# Altium schematic sheet VESC.SchDoc(VESC)
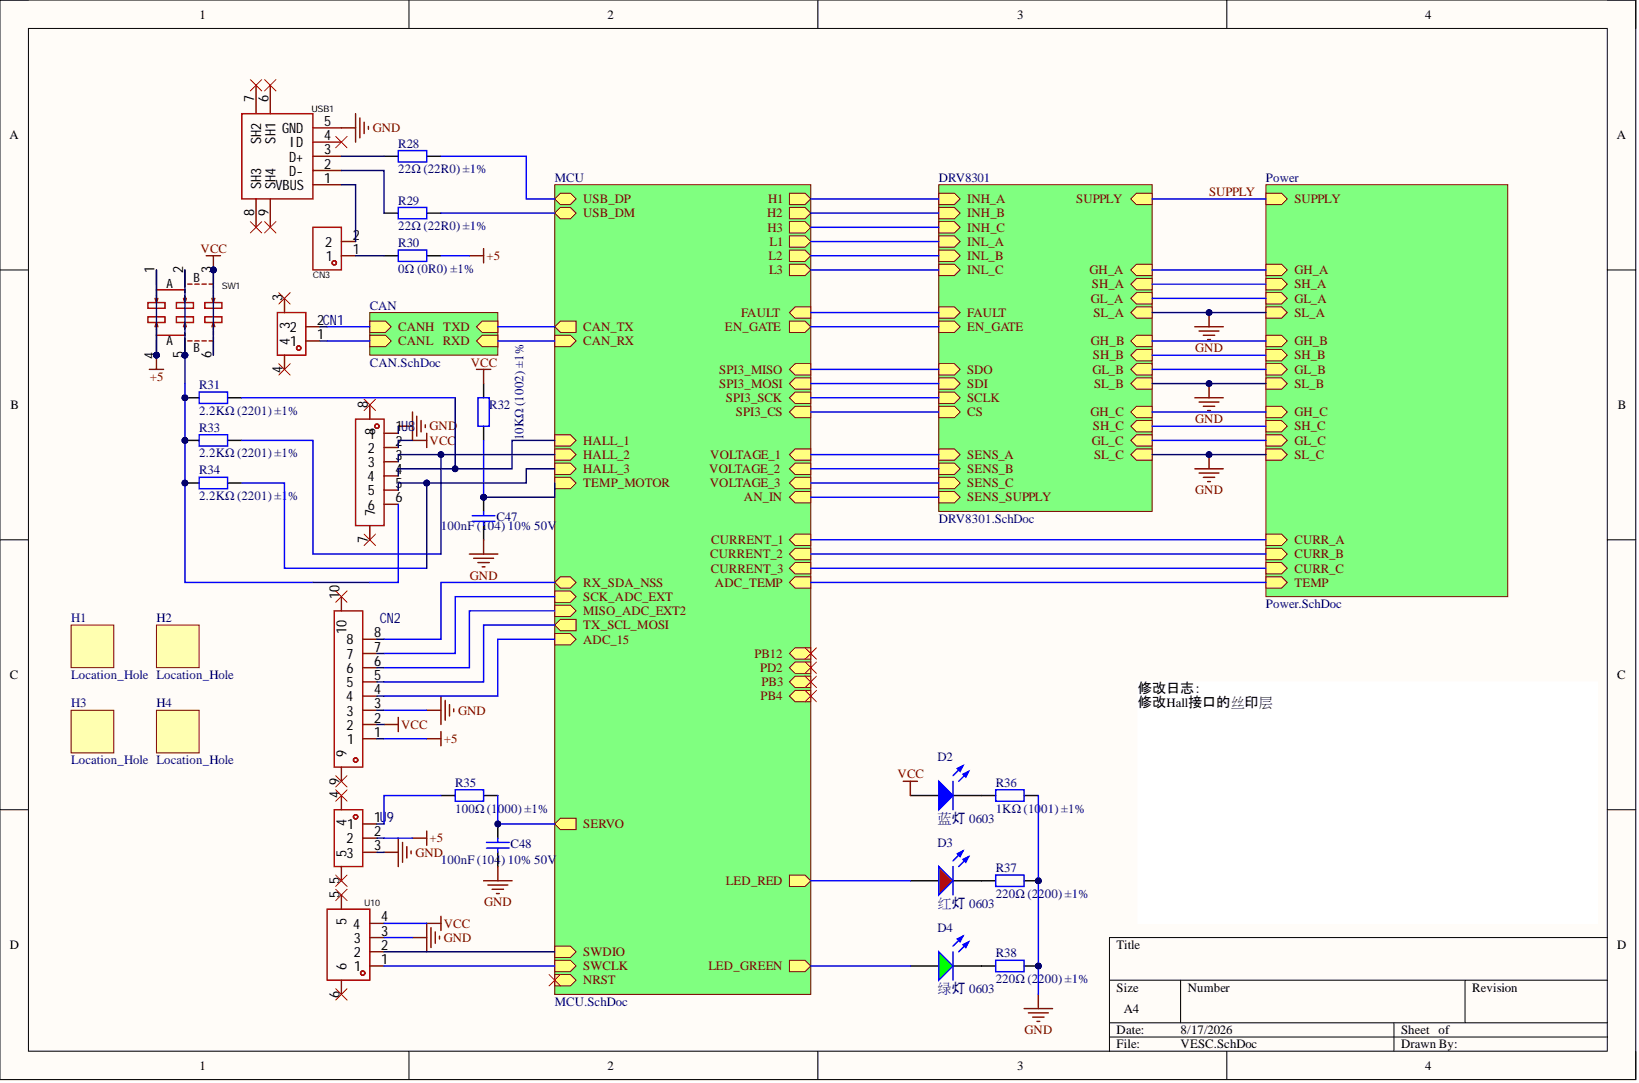
[diagram p0: VESC.SchDoc]

## Parts
C47  100nF (104) 10% 50V
C48  100nF (104) 10% 50V
CN1  GH1.25-2PWBPZ  (value not drawn)
CN2  GH1.25-8PWBPZ  (value not drawn)
CN3  DZ254S-11-02-48  (value not drawn)
D2  ?灯 0603  Package: 0603
D3  红灯 0603  Package: 0603
D4  绿灯 0603  Package: 0603
H1  Location_Hole
H2  Location_Hole
H3  Location_Hole
H4  Location_Hole
R28  22Ω (22R0) ±1%
R29  22Ω (22R0) ±1%
R30  0Ω (0R0) ±1%
R31  2.2KΩ (2201) ±1%
R32  10KΩ (1002) ±1%
R33  2.2KΩ (2201) ±1%
R34  2.2KΩ (2201) ±1%
R35  100Ω (1000) ±1%
R36  1KΩ (1001) ±1%
R37  220Ω (2200) ±1%
R38  220Ω (2200) ±1%
SW1  MST22D18G2  (value not drawn)
U8  GH1.25-6PWBPZ  (value not drawn)
U9  GH1.25-3PWBPZ  (value not drawn)
U10  WAFER-GH1.25-4PWB  (value not drawn)
USB1  MicroXNJ  (value not drawn)

## Nets
+5: CN2.1 R30.2 SW1.1 SW1.4 U9.2
GND: C47.1 C48.1 CN2.3 R36.2 R37.2 R38.2 U8.1 U9.3 U10.3 USB1.5(GND)
VCC: CN2.2 D2.A R32.2 SW1.3 SW1.6 U8.2 U10.4
NetC47_2: C47.2 R32.1
NetC48_2: C48.2 R35.2
NetCN1_1: CN1.1
NetCN1_2: CN1.2
NetCN1_3: CN1.3
NetCN1_4: CN1.4
NetCN2_4: CN2.4
NetCN2_5: CN2.5
NetCN2_6: CN2.6
NetCN2_7: CN2.7
NetCN2_8: CN2.8
NetCN2_9: CN2.9
NetCN2_10: CN2.10
NetCN3_1: CN3.1 R30.1
NetCN3_2: CN3.2 USB1.1(VBUS)
NetD2_K: D2.K R36.1
NetD3_A: D3.A
NetD3_K: D3.K R37.1
NetD4_A: D4.A
NetD4_K: D4.K R38.1
NetR28_1: R28.1 USB1.3(D+)
NetR28_2: R28.2
NetR29_1: R29.1 USB1.2(D-)
NetR29_2: R29.2
NetR31_1: R31.1 R33.1 R34.1 SW1.2 SW1.5 U8.6
NetR31_2: R31.2 U8.4
NetR33_2: R33.2 U8.3
NetR34_2: R34.2 U8.5
NetR35_1: R35.1 U9.1
NetU8_7: U8.7
NetU8_8: U8.8
NetU9_4: U9.4
NetU9_5: U9.5
NetU10_1: U10.1
NetU10_2: U10.2
NetU10_5: U10.5
NetU10_6: U10.6
NetUSB1_4: USB1.4(ID)
NetUSB1_6: USB1.6(SH1)
NetUSB1_7: USB1.7(SH2)
NetUSB1_8: USB1.8(SH3)
NetUSB1_9: USB1.9(SH4)
ADC_TEMP:
AN_IN:
CAN_RX:
CAN_TX:
CS:
CURR_A:
CURR_B:
CURR_C:
EN_GATE:
FAULT:
GH_A:
GH_B:
GH_C:
GL_A:
GL_B:
GL_C:
H1:
H2:
H3:
INL_A:
INL_B:
INL_C:
NRST:
PB3:
PB4:
PB12:
PD2:
SCLK:
SDI:
SDO:
SENS_A:
SENS_B:
SENS_C:
SH_A:
SH_B:
SH_C:
SUPPLY:

## Library data of the parts
C47 C48 = 0603 100nF (104) 10% 50V: ?片电容; Component Kind: Standard; ComponentLink1Description: 供应商?接; ComponentLink1URL: http://www.szlcsc.com/product/details_15331.html; Library Name: Miscellaneous Devices LC.IntLib; Package: 0603; Supplier: LC; SuppliersPartNumber: C14663
CN1 = GH1.25-2PWBPZ: Manufacturer: BOOMELE(博穆精密); Add into BOM: yes; Component Kind: Standard; Convert to PCB: yes; Datasheet: https://atta.szlcsc.com/upload/public/pdf/source/20211125/08A09A4909F58AF780B71FE423A00F7E.pdf; Device: GH1.25-2PWBPZ; JLCPCB Part Class: 扩展库; LCSC Part Name: GH1.25-2P卧?编带; Manufacturer Part: GH1.25-2PWBPZ; Supplier: LCSC; Supplier Footprint: -; Supplier Part: C2829251; Symbol: GH1.25-2PWBPZ; Library Name: VESC.SchLib
CN2 = GH1.25-8PWBPZ: Manufacturer: BOOMELE(博穆精密); Add into BOM: yes; Component Kind: Standard; Convert to PCB: yes; Datasheet: https://atta.szlcsc.com/upload/public/pdf/source/20211125/08A09A4909F58AF780B71FE423A00F7E.pdf; Device: GH1.25-8PWBPZ; JLCPCB Part Class: 扩展库; LCSC Part Name: GH1.25-8P卧?编带; Manufacturer Part: GH1.25-8PWBPZ; Supplier: LCSC; Supplier Footprint: -; Supplier Part: C2829257; Symbol: GH1.25-8PWBPZ; Library Name: VESC.SchLib
CN3 = DZ254S-11-02-48: Manufacturer: DEALON(德??); Add into BOM: yes; Circluar Pins/Square Pins: 方?; Pin Structure: 1x2P; Pitch: 2.54mm; Component Kind: Standard; Convert to PCB: yes; Datasheet: https://atta.szlcsc.com/upload/public/pdf/source/20230921/FE4E6D7F32A2CD9B95B1709A1ADE25FC.pdf; Device: DZ254S-11-02-48; JLCPCB Part Class: Extended Part; LCSC Part Name: ??:2.54mm 1x2P 立? 方?; Manufacturer Part: DZ254S-11-02-48; Mounting Type: 立?; Number of Pins: 2P; Number of Rows: 单排; Supplier: LCSC; Supplier Footprint: SMD,P=2.54mm; Supplier Part: C5160785; Symbol: DZ254S-11-02-48; Library Name: VESC.SchLib
D2 = 0603 ?灯: 发光二极管; Component Kind: Standard; ComponentLink1Description: 供应商?接; ComponentLink1URL: http://www.szlcsc.com/product/details_73150.html; Library Name: LED.SchLib; Supplier: LC; SuppliersPartNumber: C72041
D3 = 0603 红灯: 发光二极管; Component Kind: Standard; ComponentLink1Description: 供应商?接; ComponentLink1URL: http://www.szlcsc.com/product/details_2659.html; Library Name: LED.SchLib; Supplier: LC; SuppliersPartNumber: C2286
D4 = 0603 绿灯: 发光二极管; Component Kind: Standard; ComponentLink1Description: 供应商?接; ComponentLink1URL: http://www.szlcsc.com/product/details_73152.html; Library Name: LED.SchLib; Supplier: LC; SuppliersPartNumber: C72043
R28 R29 = 0603 22Ω (22R0) 1%: ?片电?; Component Kind: Standard; ComponentLink1Description: 供应商?接; ComponentLink1URL: http://www.szlcsc.com/product/details_24078.html; Library Name: Miscellaneous Devices LC.IntLib; Package: 0603; Supplier: LC; SuppliersPartNumber: C23345
R30 = 0603 0Ω (0R0) 1%: ?片电?; Component Kind: Standard; ComponentLink1Description: 供应商?接; ComponentLink1URL: http://www.szlcsc.com/product/details_21903.html; Library Name: Miscellaneous Devices LC.IntLib; Package: 0603; Supplier: LC; SuppliersPartNumber: C21189
R31 R33 R34 = 0603 2.2KΩ (2201) 1%: ?片电?; Component Kind: Standard; ComponentLink1Description: 供应商?接; ComponentLink1URL: http://www.szlcsc.com/product/details_4597.html; Library Name: Miscellaneous Devices LC.IntLib; Package: 0603; Supplier: LC; SuppliersPartNumber: C4190
R32 = 0603 10KΩ (1002) 1%: ?片电?; Component Kind: Standard; ComponentLink1Description: 供应商?接; ComponentLink1URL: http://www.szlcsc.com/product/details_26547.html; Library Name: Miscellaneous Devices LC.IntLib; Package: 0603; Supplier: LC; SuppliersPartNumber: C25804
R35 = 0603 100Ω (1000) 1%: ?片电?; Component Kind: Standard; ComponentLink1Description: 供应商?接; ComponentLink1URL: http://www.szlcsc.com/product/details_23502.html; Library Name: Miscellaneous Devices LC.IntLib; Package: 0603; Supplier: LC; SuppliersPartNumber: C22775
R36 = 0603 1KΩ (1001) 1%: ?片电?; Component Kind: Standard; ComponentLink1Description: 供应商?接; ComponentLink1URL: http://www.szlcsc.com/product/details_21904.html; Library Name: Miscellaneous Devices LC.IntLib; Package: 0603; Supplier: LC; SuppliersPartNumber: C21190
R37 R38 = 0603 220Ω (2200) 1%: ?片电?; Component Kind: Standard; ComponentLink1Description: 供应商?接; ComponentLink1URL: http://www.szlcsc.com/product/details_23689.html; Library Name: Miscellaneous Devices LC.IntLib; Package: 0603; Supplier: LC; SuppliersPartNumber: C22962
SW1 = MST22D18G2: Manufacturer: SHOU HAN(??); Add into BOM: yes; Circuit: 双刀双掷; Current Rating (DC): 50mA; Mechanical Life: 1万次; Pin Style: SMD接片; Switch Height: 3.45mm; Switch Length: 9.1mm; Switch Width: 3.6mm; Voltage Rating (DC): 12V; Actuator Color: -; Actuator Style: -; Component Kind: Standard; Convert to PCB: yes; Current Rating (AC): -; Datasheet: https://atta.szlcsc.com/upload/public/pdf/source/20190925/C431544_D32DFFD935A96A6C5145903882D4ABA1.pdf; Device: MST22D18G2; JLCPCB Part Class: Extended Part; LCSC Part Name: 两档立式?片 6?拨动 柄?2.0MM; Manufacturer Part: MST22D18G2; Mounting Style: 立?; Operating Temperature: -30℃~+80℃; Supplier: LCSC; Supplier Footprint: SMD,3.6x9.1mm; Supplier Part: C431544; Symbol: MST22D18G2; Voltage Rating (AC): -; Library Name: VESC.SchLib
U8 = GH1.25-6PWBPZ: Manufacturer: BOOMELE(博穆精密); Add into BOM: yes; Component Kind: Standard; Convert to PCB: yes; Datasheet: https://atta.szlcsc.com/upload/public/pdf/source/20211125/08A09A4909F58AF780B71FE423A00F7E.pdf; Device: GH1.25-6PWBPZ; JLCPCB Part Class: 扩展库; LCSC Part Name: GH1.25-6P卧?编带; Manufacturer Part: GH1.25-6PWBPZ; Supplier: LCSC; Supplier Footprint: -; Supplier Part: C2829255; Symbol: GH1.25-6PWBPZ; Library Name: VESC.SchLib
U9 = GH1.25-3PWBPZ: Manufacturer: BOOMELE(博穆精密); Add into BOM: yes; Component Kind: Standard; Convert to PCB: yes; Datasheet: https://atta.szlcsc.com/upload/public/pdf/source/20211125/08A09A4909F58AF780B71FE423A00F7E.pdf; Device: GH1.25-3PWBPZ; JLCPCB Part Class: 扩展库; LCSC Part Name: GH1.25-3P卧?编带; Manufacturer Part: GH1.25-3PWBPZ; Supplier: LCSC; Supplier Footprint: -; Supplier Part: C2829252; Symbol: GH1.25-3PWBPZ; Library Name: VESC.SchLib
U10 = GH1.25-4PWBPZ: Manufacturer: XUNPU(?普); Add into BOM: yes; Current Rating (Max): 1A; Number of PINs Per Row: 4; Pins Structure: 1x4P; Pitch: 1.25mm; Reference Series: GH; Component Kind: Standard; Convert to PCB: yes; Datasheet: https://atta.szlcsc.com/upload/public/pdf/source/20220527/CB8773BFB0913C3B8ABD62CB38BA101A.pdf; Device: WAFER-GH1.25-4PWB; JLCPCB Part Class: Extended Part; LCSC Part Name: 1x4P ??:1.25mm 卧? 系列:GH; Manufacturer Part: WAFER-GH1.25-4PWB; Mounting Style: 卧?; Number of Pins: 4P; Number of Rows: 1; Operating Temperature Range: -25℃~+85℃; Supplier: LCSC; Supplier Footprint: SMD,P=1.25mm,卧?; Supplier Part: C3029379; Symbol: WAFER-GH1.25-4PWB; Library Name: VESC.SchLib
USB1 = MicroXNJ: Manufacturer: SHOU HAN(??); Add into BOM: yes; Connect-Disconnect Life: 5千次; Connector Type: Micro-B; Core Colour: ??; Current Rating - Power (Max): 1.8A; Gender: 母; Number of Contacts: 5P; Number of Ports: 1; Rated Voltage (Max): 30V; Component Kind: Standard; Convert to PCB: yes; Datasheet: https://atta.szlcsc.com/upload/public/pdf/source/20220415/2494EDFF499AF6F852F7C820374B6AF3.pdf; Device: MicroXNJ; JLCPCB Part Class: Extended Part; LCSC Part Name: Micro-B 母 卧?; Manufacturer Part: MicroXNJ; Mounting Style: 卧?; Operating Temperature Range: -20℃~+85℃; Standard: USB 2.0; Supplier: LCSC; Supplier Footprint: SMD; Supplier Part: C404969; Symbol: MICROXNJ; Library Name: VESC.SchLib
H1-H4 = Location_Hole: Component Kind: Standard; Library Name: VESC.SchLib
